# Altium schematic sheet CW553_LCD_Connector.SchDoc
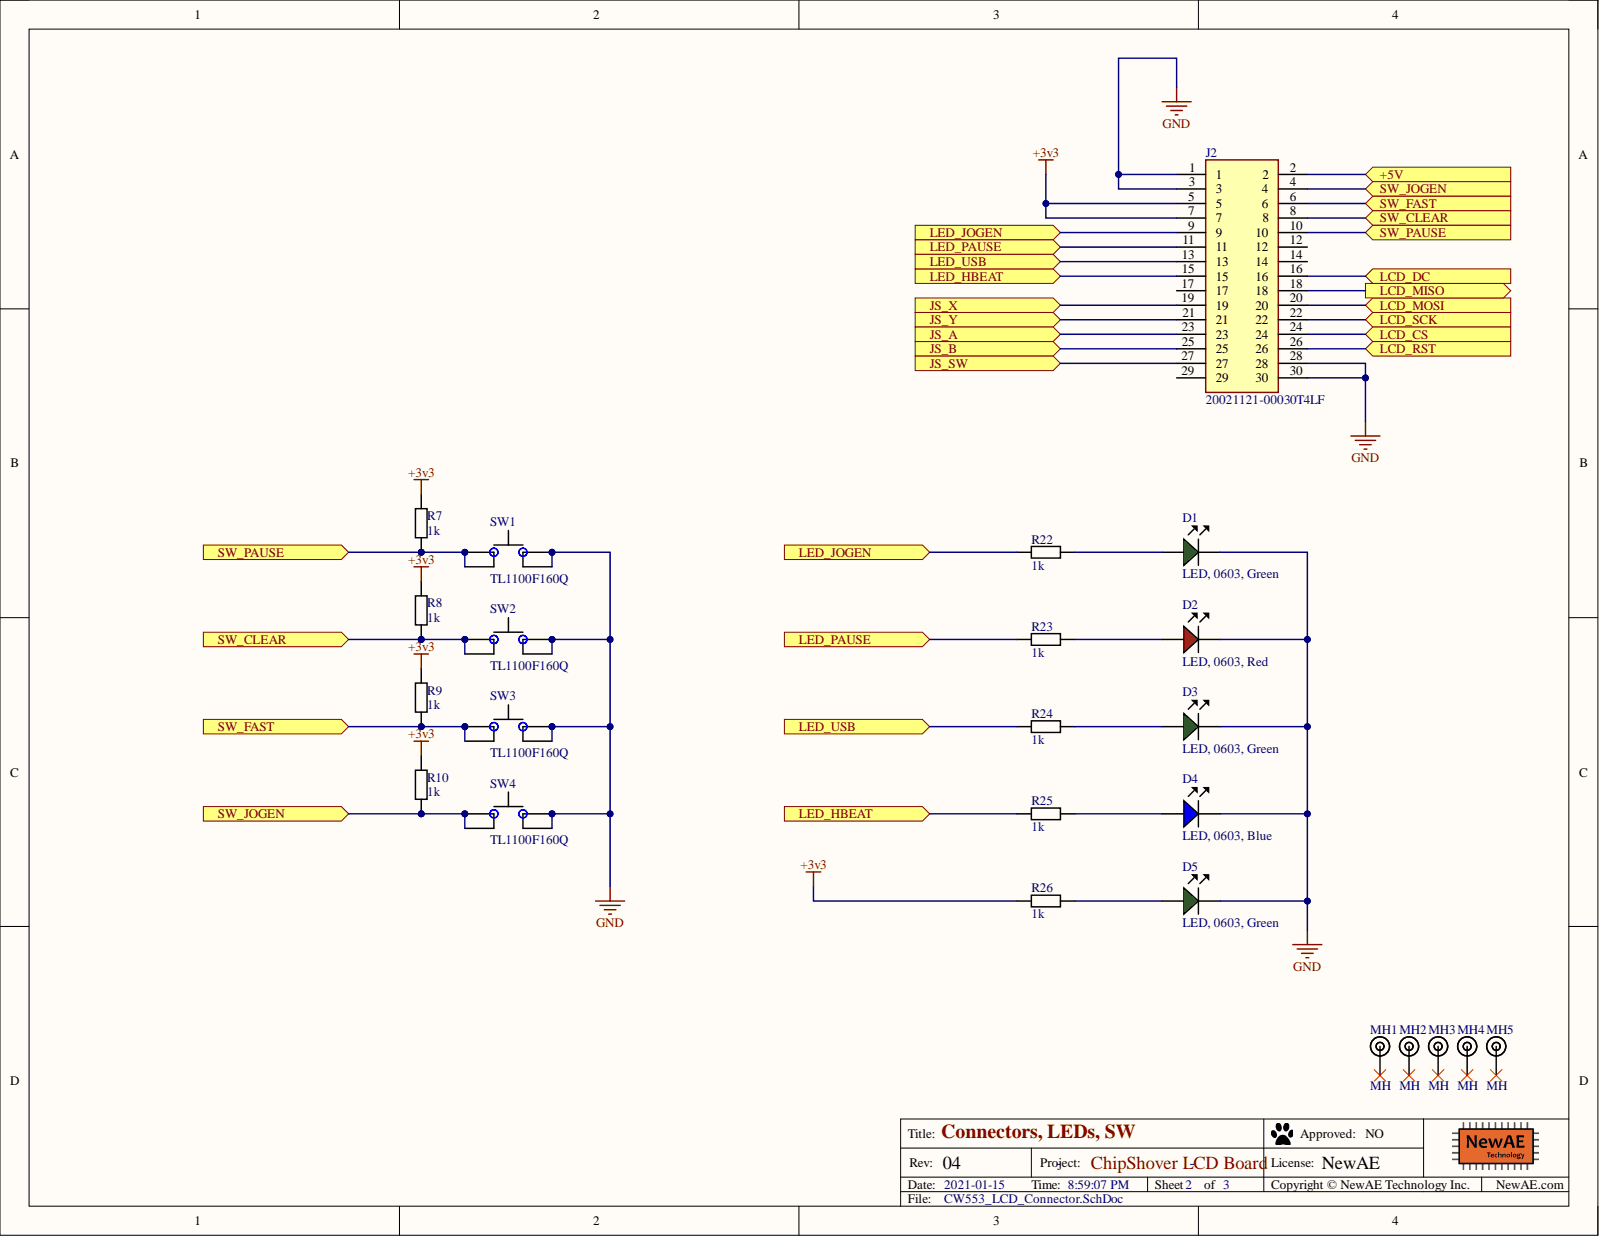
[diagram p0: CW553_LCD_Connector.SchDoc]

## Parts
D1  LED, 0603, Green  [LED-0603-GREEN]
D2  LED, 0603, Red  [LED-0603-RED]
D3  LED, 0603, Green  [LED-0603-GREEN]
D4  LED, 0603, Blue  [LED-0603-BLUE]
D5  LED, 0603, Green  [LED-0603-GREEN]
J2  20021121-00030T4LF  [2002112100030T4LF]
MH1  MH  [MOUNT_#4_TIGHT]  (mechanical)
MH2  MH  [MOUNT_#4_TIGHT]  (mechanical)
MH3  MH  [MOUNT_#4_TIGHT]  (mechanical)
MH4  MH  [MOUNT_#4_TIGHT]  (mechanical)
MH5  MH  [MOUNT_#4_TIGHT]  (mechanical)
R7  1k  [0603]
R8  1k  [0603]
R9  1k  [0603]
R10  1k  [0603]
R22  1k  [0603]
R23  1k  [0603]
R24  1k  [0603]
R25  1k  [0603]
R26  1k  [0603]
SW1  TL1100F160Q  [SW-TL1100F160Q]
SW2  TL1100F160Q  [SW-TL1100F160Q]
SW3  TL1100F160Q  [SW-TL1100F160Q]
SW4  TL1100F160Q  [SW-TL1100F160Q]

## Ports
+5V, JS_A, JS_B, JS_SW, JS_X, JS_Y, LCD_CS, LCD_DC, LCD_MISO, LCD_MOSI, LCD_RST, LCD_SCK, LED_HBEAT, LED_JOGEN, LED_PAUSE, LED_USB, SW_CLEAR, SW_FAST, SW_JOGEN, SW_PAUSE

## Nets
+3v3: J2.5 J2.7 R7.1 R8.1 R9.1 R10.1 R26.1
GND: D1.K D2.K D3.K D4.K D5.K J2.1 J2.3 J2.28 J2.30 SW1.2 SW1.3 SW2.2 SW2.3 SW3.2 SW3.3 SW4.2 SW4.3
+5V: J2.2 ; port +5V
JS_A: J2.23 ; port JS_A
JS_B: J2.25 ; port JS_B
JS_SW: J2.27 ; port JS_SW
JS_X: J2.19 ; port JS_X
JS_Y: J2.21 ; port JS_Y
LCD_CS: J2.24 ; port LCD_CS
LCD_DC: J2.16 ; port LCD_DC
LCD_MISO: J2.18 ; port LCD_MISO
LCD_MOSI: J2.20 ; port LCD_MOSI
LCD_RST: J2.26 ; port LCD_RST
LCD_SCK: J2.22 ; port LCD_SCK
LED_HBEAT: J2.15 R25.1 ; port LED_HBEAT
LED_JOGEN: J2.9 R22.1 ; port LED_JOGEN
LED_PAUSE: J2.11 R23.1 ; port LED_PAUSE
LED_USB: J2.13 R24.1 ; port LED_USB
SW_CLEAR: J2.8 R8.2 SW2.1 SW2.4 ; port SW_CLEAR
SW_FAST: J2.6 R9.2 SW3.1 SW3.4 ; port SW_FAST
SW_JOGEN: J2.4 R10.2 SW4.1 SW4.4 ; port SW_JOGEN
SW_PAUSE: J2.10 R7.2 SW1.1 SW1.4 ; port SW_PAUSE
NetD1_A: D1.A R22.2
NetD2_A: D2.A R23.2
NetD3_A: D3.A R24.2
NetD4_A: D4.A R25.2
NetD5_A: D5.A R26.2

Not connected: J2 12 14 17 29; MH1 1; MH2 1; MH3 1; MH4 1; MH5 1

## Library data of the parts
D1 D3 D5 = LEDCHIP_0603_GREEN: Chip LED, Green; Manufacture 1: Kingbright; Manufacture Part Number 1: APT1608CGCK; Component Group: Optical; Database Table Name: main; PP Material Stack: 16; PP Rotation: -90; Component Kind: Standard; Component Type: Standard; Library Name: NewAE_AltiumLib.DBLib; Mounting Technology: SMT; Part Number: LEDCHIP_0603_GREEN; Pin Count: 2; Supplier 1: Digi-Key; Supplier Part Number 1: 754-1116-1-ND
D2 = LEDCHIP_0603_RED: Chip LED, Red; Manufacture 1: Kingbright; Manufacture Part Number 1: APT1608SRCPRV; Component Group: Optical; Database Table Name: main; PP Material Stack: 15; PP Rotation: -90; Component Kind: Standard; Component Type: Standard; Library Name: NewAE_AltiumLib.DBLib; Mounting Technology: SMT; Part Number: LEDCHIP_0603_RED; Pin Count: 2; Supplier 1: Digi-Key; Supplier Part Number 1: 754-1122-1-ND
D4 = LEDCHIP_0603_BLUE: Chip LED, Blue; Manufacture 1: Kingbright; Component Group: Optical; Database Table Name: main; Component Kind: Standard; Component Type: Standard; Library Name: NewAE_AltiumLib.DBLib; Mounting Technology: SMT; Part Number: LEDCHIP_0603_BLUE; Pin Count: 2
J2 = 20021121-00030T4LF: Connector; Manufacturer_Name: Amphenol; Component Kind: Standard; Datasheet Link: https://cdn.amphenol-icc.com/media/wysiwyg/files/drawing/20021121.pdf; Height: 4.85mm; Manufacturer_Part_Number: 20021121-00030T4LF
MH1-MH5 = MOUNT_#4_TIGHT: Machine screw 4-40 Mounting Hole; Component Group: Mechanical; Database Table Name: main; PP Material Stack: 0; Component Type: Mechanical; Library Name: NewAE_AltiumLib.DBLib; Part Number: MOUNT_#4_TIGHT; Pin Count: 1; PP Rotation: 0
R7-R10 R22-R26 = RCHIP-1K-0603: 1k res, 0603, 1%, 1/10W; Manufacture 1: Yageo; Manufacture Part Number 1: RC0603FR-071KL; Case-Metric: 1608; Component Group: Resistor; Database Table Name: main; Rated Power: 0.1W; Rated Voltage: 75VDC; Case-EIA: 0603; Component Kind: Standard; Component Type: Standard; Library Name: NewAE_AltiumLib.DBLib; Mounting Technology: SMT; Part Number: RCHIP-1K-0603; Pin Count: 2; Supplier 1: Digi-Key; Supplier Part Number 1: 311-1.00KHRCT-ND; Temperature Range: -55C to 155C; Tolerance: 1%; Value: 1k
SW1-SW4 = TL1100F160Q: Tactile Switch; Manufacture 1: E-Switch; Database Table Name: main; PP Material Stack: 0; Component Group: Switch; Component Kind: Standard; Component Type: Standard; Library Name: NewAE_AltiumLib.DBLib; Manufacture Part Number 1: TL1100F160Q; Part Number: TL1100F160Q; Pin Count: 4; PP Rotation: 0; Supplier 1: Digi-Key; Supplier Part Number 1: EG1821-ND
